# Altium schematic sheet 07-FPGA.SchDoc(07-FPGA)
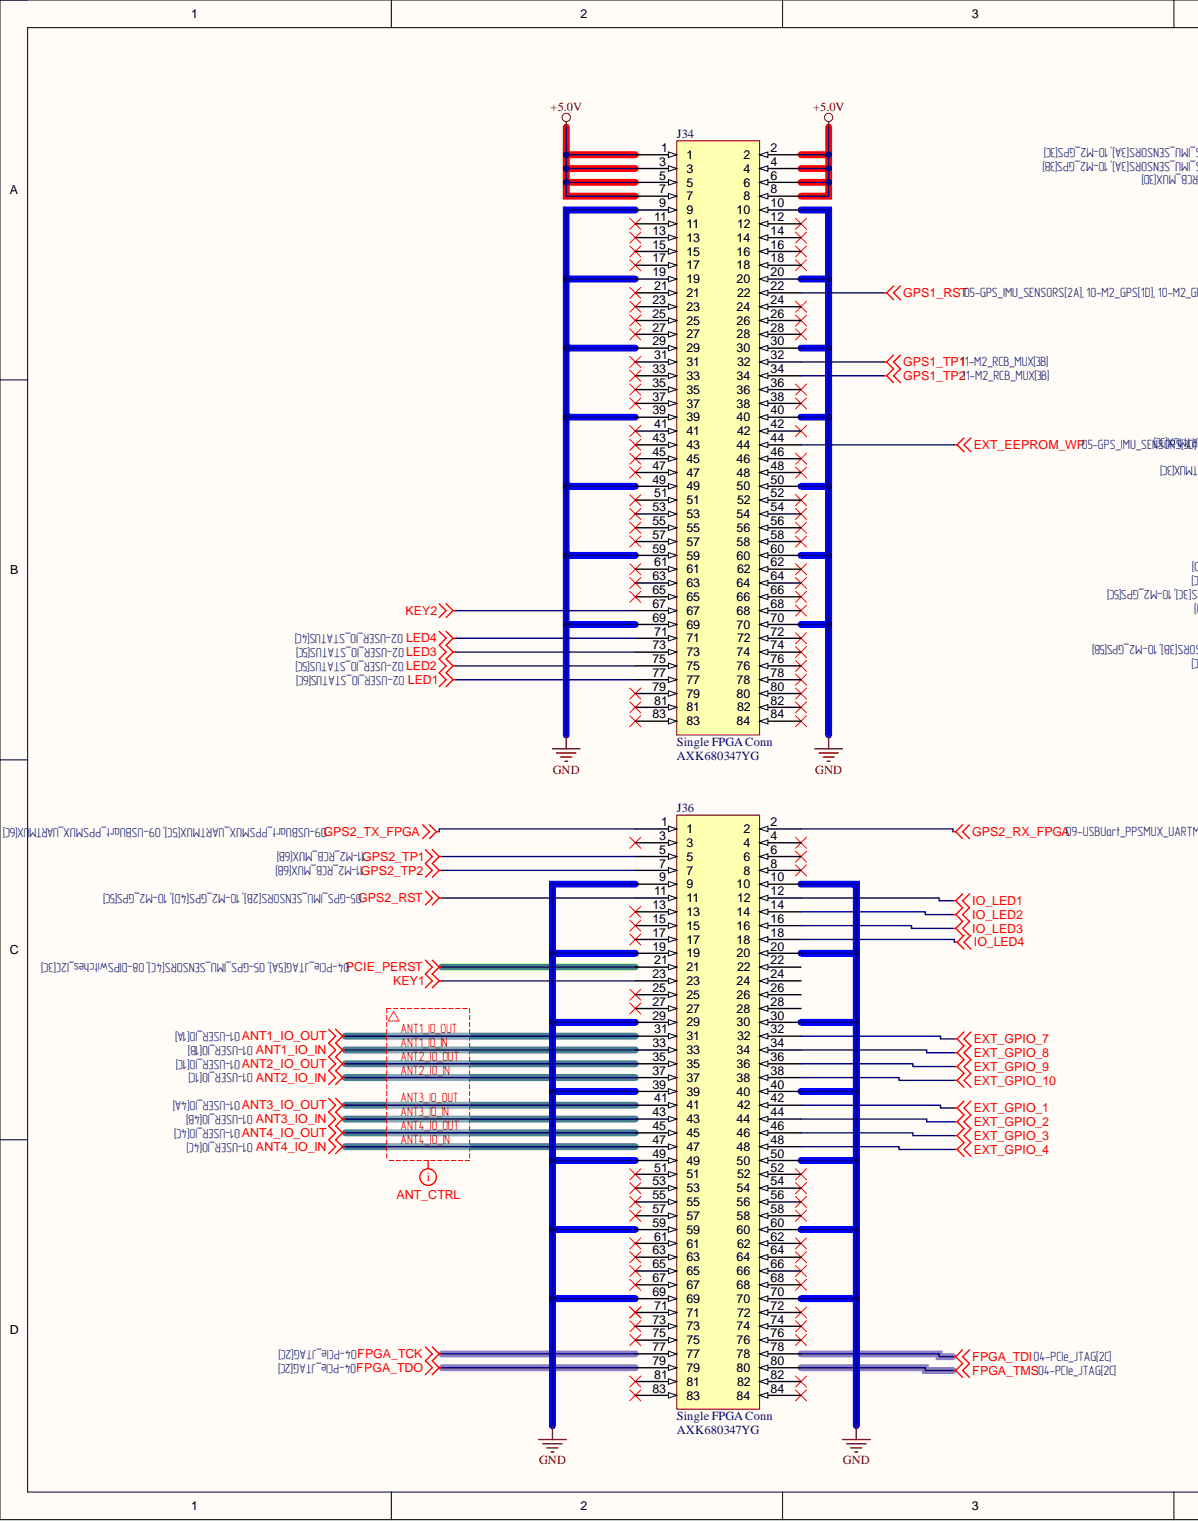
[diagram p0: 07-FPGA.SchDoc, left]
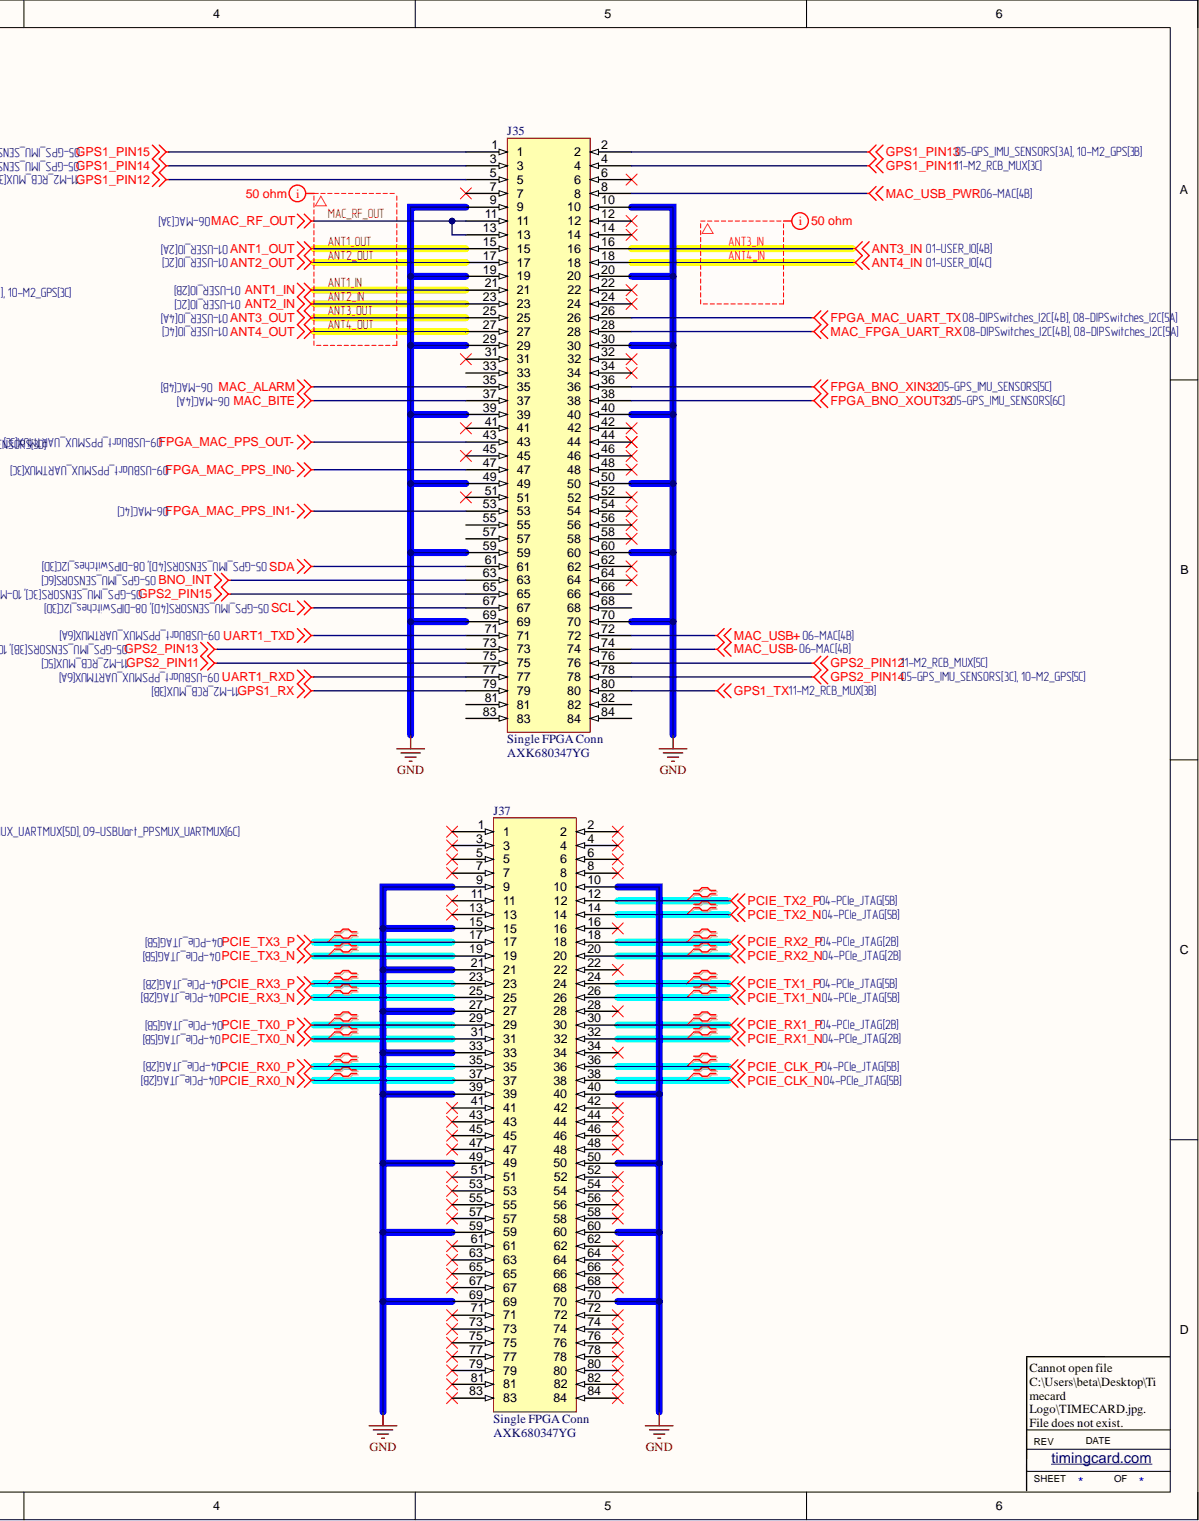
[diagram p1: 07-FPGA.SchDoc, right]

## Parts
J34  Single FPGA Conn  [SingleFPGAConn]
J35  Single FPGA Conn  [SingleFPGAConn]
J36  Single FPGA Conn  [SingleFPGAConn]
J37  Single FPGA Conn  [SingleFPGAConn]

## Nets
+5.0V: J34.1 J34.2 J34.3 J34.4 J34.5 J34.6 J34.7 J34.8
BNO_INT: J35.63
EXT_EEPROM_WP: J34.44
EXT_GPIO_1: J36.42
EXT_GPIO_2: J36.44
EXT_GPIO_3: J36.46
EXT_GPIO_4: J36.48
EXT_GPIO_7: J36.32
EXT_GPIO_8: J36.34
EXT_GPIO_9: J36.36
EXT_GPIO_10: J36.38
FPGA_BNO_XIN32: J35.36
FPGA_BNO_XOUT32: J35.38
FPGA_MAC_PPS_IN0-: J35.47
FPGA_MAC_PPS_IN1-: J35.53
FPGA_MAC_PPS_OUT-: J35.43
FPGA_MAC_UART_TX: J35.26
FPGA_TCK: J36.77
FPGA_TDI: J36.78
FPGA_TDO: J36.79
FPGA_TMS: J36.80
GND: J34.9 J34.10 J34.19 J34.20 J34.29 J34.30 J34.39 J34.40 J34.49 J34.50 J34.59 J34.60 J34.69 J34.70 J35.9 J35.10 J35.19 J35.20 J35.29 J35.30 J35.39 J35.40 J35.49 J35.50 J35.59 J35.60 J35.69 J35.70 J36.9 J36.10 J36.19 J36.20 J36.29 J36.30 J36.39 J36.40 J36.49 J36.50 J36.59 J36.60 J36.69 J36.70 J37.9 J37.10 J37.15 J37.21 J37.27 J37.33 J37.39 J37.40 J37.49 J37.50 J37.59 J37.60 J37.69 J37.70
GPS1_PIN11: J35.4
GPS1_PIN12: J35.5
GPS1_PIN13: J35.2
GPS1_PIN14: J35.3
GPS1_PIN15: J35.1
GPS1_RST: J34.22
GPS1_RX: J35.79
GPS1_TP1: J34.32
GPS1_TP2: J34.34
GPS1_TX: J35.80
GPS2_PIN11: J35.75
GPS2_PIN12: J35.76
GPS2_PIN13: J35.73
GPS2_PIN14: J35.78
GPS2_PIN15: J35.65
GPS2_RST: J36.11
GPS2_RX_FPGA: J36.2
GPS2_TP1: J36.5
GPS2_TP2: J36.7
GPS2_TX_FPGA: J36.1
IO_LED1: J36.12
IO_LED2: J36.14
IO_LED3: J36.16
IO_LED4: J36.18
KEY1: J36.23
KEY2: J34.67
LED1: J34.77
LED2: J34.75
LED3: J34.73
LED4: J34.71
MAC_ALARM: J35.35
MAC_BITE: J35.37
MAC_FPGA_UART_RX: J35.28
MAC_USB+: J35.72
MAC_USB-: J35.74
MAC_USB_PWR: J35.8
PCIE_CLK_N: J37.38
PCIE_CLK_P: J37.36
PCIE_PERST: J36.21
PCIE_RX0_N: J37.37
PCIE_RX0_P: J37.35
PCIE_RX1_N: J37.32
PCIE_RX1_P: J37.30
PCIE_RX2_N: J37.20
PCIE_RX2_P: J37.18
PCIE_RX3_N: J37.25
PCIE_RX3_P: J37.23
PCIE_TX0_N: J37.31
PCIE_TX0_P: J37.29
PCIE_TX1_N: J37.26
PCIE_TX1_P: J37.24
PCIE_TX2_N: J37.14
PCIE_TX2_P: J37.12
PCIE_TX3_N: J37.19
PCIE_TX3_P: J37.17
SCL: J35.67
SDA: J35.61
UART1_RXD: J35.77
UART1_TXD: J35.71
ANT1_IN: J35.21
ANT1_IO_IN: J36.33
ANT1_IO_OUT: J36.31
ANT1_OUT: J35.15
ANT2_IN: J35.23
ANT2_IO_IN: J36.37
ANT2_IO_OUT: J36.35
ANT2_OUT: J35.17
ANT3_IN: J35.16
ANT3_IO_IN: J36.43
ANT3_IO_OUT: J36.41
ANT3_OUT: J35.25
ANT4_IN: J35.18
ANT4_IO_IN: J36.47
ANT4_IO_OUT: J36.45
ANT4_OUT: J35.27
MAC_RF_OUT: J35.11 J35.13

Not connected: J34 11 12 13 14 15 16 17 18 21 23 24 25 26 27 28 31 33 35 36 37 38 41 42 43 45 46 47 48 51 52 53 54 55 56 57 58 61 62 63 64 65 66 68 72 74 76 78 79 80 81 82 83 84; J35 6 7 12 14 22 24 31 32 33 34 41 42 44 45 46 48 51 52 54 55 56 57 58 62 64 66 68 81 82 83 84; J36 3 4 6 8 13 15 17 22 24 25 26 27 28 51 52 53 54 55 56 57 58 61 62 63 64 65 66 67 68 71 72 73 74 75 76 81 82 83 84; J37 1 2 3 4 5 6 7 8 11 13 16 22 28 34 41 42 43 44 45 46 47 48 51 52 53 54 55 56 57 58 61 62 63 64 65 66 67 68 71 72 73 74 75 76 77 78 79 80 81 82 83 84

## Library data of the parts
J34-J37 = Single FPGA Conn: AXK680347YG; Component Kind: Standard; Library Name: FPGA_CONN.SchLib; Part Number: AXK680347YG; Supplier 1: Digi-Key; Supplier Part Number 1: 255-3246-1-ND
